# Altium schematic sheet BTT_M157_Interface1_V0.3.SchDoc(BTT_M157_Interface1_V0.3)
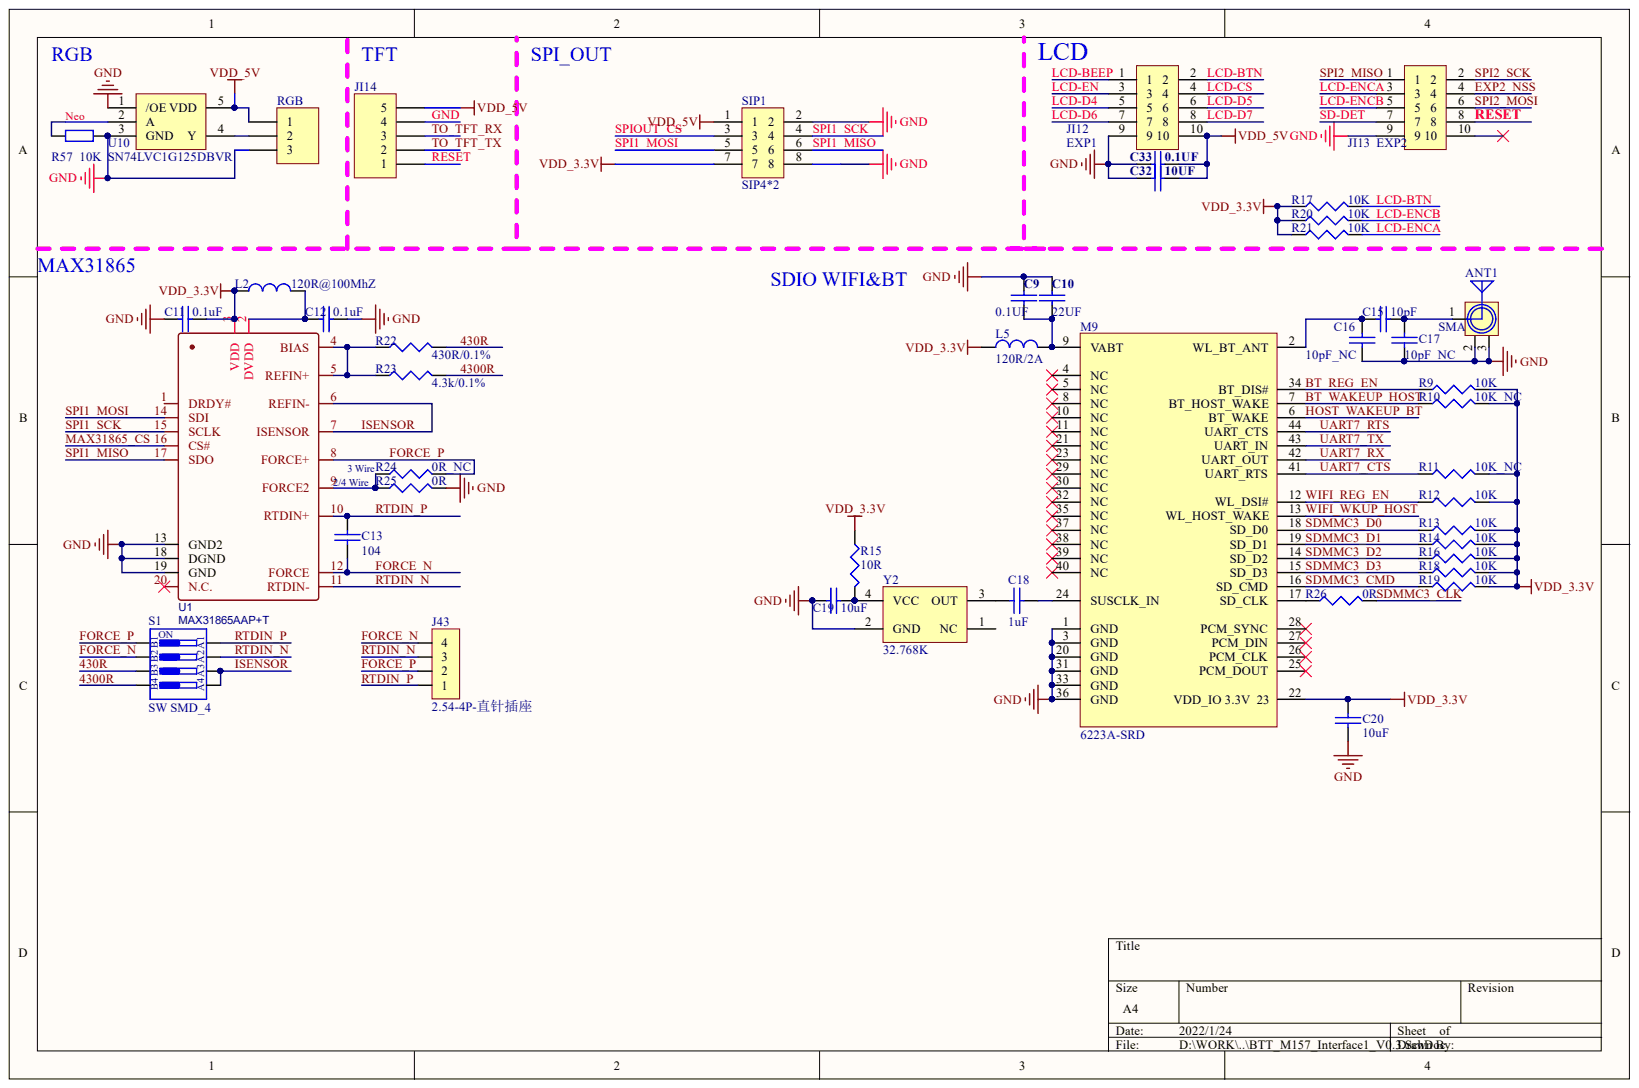
[diagram p0: BTT_M157_Interface1_V0.3.SchDoc]

## Parts
ANT1  SMA  [SMA-TH]
C9  0.1UF  [0603C]
C10  22UF  [0805C]
C11  0.1uF  [0603C]
C12  0.1uF  [0603C]
C13  104  [0603c]
C15  10pF  [0603C]
C16  10pF_NC  [0603C]
C17  10pF_NC  [0603C]
C18  1uF  [0603C]
C19  10uF  [0805C]
C20  10uF  [0805C]
C32  10UF  [0805C]
C33  0.1UF  [0603c]
J43  2.54-4P-直针插座  [2.54-4P-直针插座]
JI12  EXP1  [2*5_2.54-简牛插座]
JI13  EXP2  [2*5_2.54-简牛插座]
JI14  TFT  [SIP5-2.54-BLACK]
L2  120R@100MhZ  [0805L]
L5  120R/2A  [0603L]
M9  6223A-SRD  [Module_RTL8723DS]
R9  10K  [0603R]
R10  10K_NC  [0603R]
R11  10K_NC  [0603R]
R12  10K  [0603R]
R13  10K  [0603R]
R14  10K  [0603R]
R15  10R  [0603R]
R16  10K  [0603R]
R17  10K  [0603R]
R18  10K  [0603R]
R19  10K  [0603R]
R20  10K  [0603R]
R21  10K  [0603R]
R22  430R/0.1%  [0603R]
R23  4.3k/0.1%  [0603R]
R24  0R_NC  [0603R]
R25  0R  [0603R]
R26  0R  [0603R]
R57  value 10K  [0603-R]  (hidden comment: 4.7K)
RGB  2.54-3P-直针插座  [XH2.54-3P-直针插座]  (value not drawn)
S1  SW SMD_4  [SW_SMT_04_1.27]
SIP1  SIP4*2  [SIP4*2-2.54-BIACK]
U1  MAX31865AAP+T  [SSOP20]
U10  SN74LVC1G125DBVR  [sot23-5]
Y2  32.768K  [XTAL_3225]

## Nets
VDD_3.3V: C11.2 C20.1 L2.1 L5.1 M9.22 R9.2 R10.2 R11.2 R12.2 R13.2 R14.2 R15.1 R16.2 R17.1 R18.2 R19.2 R20.1 R21.1 SIP1.7 U1.3(VDD)
VDD_5V: C32.2 C33.1 JI12.10 JI14.5 RGB.1 SIP1.1 U10.5(VDD)
430R: R22.2 S1.6(B3)
4300R: R23.2 S1.5(B4)
BT_REG_EN: M9.34(BT_DIS#) R9.1
BT_WAKEUP_HOST: M9.7(BT_HOST_WAKE) R10.1
EXP2_NSS: JI13.4
FORCE_N: C13.2 J43.4 S1.7(B2) U1.12(FORCE)
FORCE_P: J43.2 R24.2 S1.8(B1) U1.8(FORCE+)
GND: ANT1.2 ANT1.3 C9.1 C10.1 C11.1 C12.2 C16.1 C17.1 C19.2 C20.2 C32.1 C33.2 JI12.9 JI13.9 JI14.4 M9.1(GND) M9.3(GND) M9.20(GND) M9.31(GND) M9.33(GND) M9.36(GND) R25.2 R57.1 RGB.3 SIP1.2 SIP1.8 U1.13(GND2) U1.18(DGND) U1.19(GND) U10.1(/OE) U10.3(GND) Y2.2(GND)
HOST_WAKEUP_BT: M9.6(BT_WAKE)
ISENSOR: S1.3(A3) S1.4(A4) U1.6(REFIN-) U1.7(ISENSOR)
LCD-BEEP: JI12.1
LCD-BTN: JI12.2 R17.2
LCD-CS: JI12.4
LCD-D4: JI12.5
LCD-D5: JI12.6
LCD-D6: JI12.7
LCD-D7: JI12.8
LCD-EN: JI12.3
LCD-ENCA: JI13.3 R21.2
LCD-ENCB: JI13.5 R20.2
MAX31865_CS: U1.16(CS#)
NEO: R57.2 U10.2(A)
RESET: JI13.8 JI14.1
RTDIN_N: J43.3 S1.2(A2) U1.11(RTDIN-)
RTDIN_P: C13.1 J43.1 S1.1(A1) U1.10(RTDIN+)
SD-DET: JI13.7
SDMMC3_CLK: R26.2
SDMMC3_CMD: M9.16(SD_CMD) R19.1
SDMMC3_D0: M9.18(SD_D0) R13.1
SDMMC3_D1: M9.19(SD_D1) R14.1
SDMMC3_D2: M9.14(SD_D2) R16.1
SDMMC3_D3: M9.15(SD_D3) R18.1
SPI1_MISO: SIP1.6 U1.17(SDO)
SPI1_MOSI: SIP1.5 U1.14(SDI)
SPI1_SCK: SIP1.4 U1.15(SCLK)
SPI2_MISO: JI13.1
SPI2_MOSI: JI13.6
SPI2_SCK: JI13.2
SPIOUT_CS: SIP1.3
TO_TFT_RX: JI14.3
TO_TFT_TX: JI14.2
UART7_CTS: M9.41(UART_RTS) R11.1
UART7_RTS: M9.44(UART_CTS)
UART7_RX: M9.42(UART_OUT)
UART7_TX: M9.43(UART_IN)
WIFI_REG_EN: M9.12(WL_DSI#) R12.1
WIFI_WKUP_HOST: M9.13(WL_HOST_WAKE)
NetANT1_1: ANT1.1 C15.2 C17.2
NetC9_2: C9.2 C10.2 L5.2 M9.9(VABT)
NetC12_1: C12.1 L2.2 U1.2(DVDD)
NetC15_1: C15.1 C16.2 M9.2(WL_BT_ANT)
NetC18_1: C18.1 Y2.3(OUT)
NetC18_2: C18.2 M9.24(SUSCLK_IN)
NetC19_1: C19.1 R15.2 Y2.4(VCC)
NetM9_17: M9.17(SD_CLK) R26.1
NetR22_1: R22.1 R23.1 U1.4(BIAS) U1.5(REFIN+)
NetR24_1: R24.1 R25.1 U1.9(FORCE2)
NetRGB_2: RGB.2 U10.4(Y)

Not connected: JI13 10; M9 4(NC) 5(NC) 8(NC) 10(NC) 11(NC) 21(NC) 23(NC) 25(PCM_DOUT) 26(PCM_CLK) 27(PCM_DIN) 28(PCM_SYNC) 29(NC) 30(NC) 32(NC) 35(NC) 37(NC) 38(NC) 39(NC) 40(NC); U1 1(DRDY#) 20(N.C.); Y2 1(NC)

## Library data of the parts
ANT1 = SMA: SMA-2.4G射频天线接口; 版权归属: 源创客; BOM名称: SMA-2.4G射频天线接口; Component Kind: Standard; 学习资源: www.ooocke.com; Library Name: My Useful Discrete Devices.SchLib
C9 C10 C32 C33 = CAP: Component Kind: Standard; Library Name: liroqi.SchLib
C11-C13 C15-C20 = CAP: Capacitor; Component Kind: Standard; Library Name: My Useful Discrete Devices.SchLib
J43 = CON4: Connector; Component Kind: Standard; Library Name: My Useful Discrete Devices.SchLib
JI12 JI13 = con2X5: Component Kind: Standard; Library Name: My Useful Discrete Devices.SchLib
JI14 = Header 5: Header, 5-Pin; Component Kind: Standard; LatestRevisionDate: 17-Jul-2002; LatestRevisionNote: Re-released for DXP Platform.; Library Name: Miscellaneous Connectors.IntLib; Signal Integrity: Connector; Publisher: Altium Limited
L2 L5 = INDUCTOR: Component Kind: Standard; Library Name: My Useful Discrete Devices.SchLib
M9 = 6223A-SRD: 欧智通SDIO  WIFI/BLE模块; Component Kind: Standard; Library Name: My Useful IC.SchLib
R9-R26 = RES1: Component Kind: Standard
  R9-R16 R18 R19 R22-R26: Library Name: My Useful Discrete Devices.SchLib
  R17 R20 R21: Library Name: 常用库.SCHLIB
R57 = Res2: Resistor; Component Kind: Standard; LatestRevisionDate: 17-Jul-2002; LatestRevisionNote: Re-released for DXP Platform.; Library Name: Miscellaneous Devices.IntLib; PackageDescription: Axial Device, Thru-Hole; 2 Leads; 0.4 in Pin Spacing; PackageReference: AXIAL-0.4; Published: 8-Jun-2000; Signal Integrity: Res; Simulation: RESISTOR; Publisher: Altium Limited
RGB = Header 3: Header, 3-Pin; Component Kind: Standard; LatestRevisionDate: 17-Jul-2002; LatestRevisionNote: Re-released for DXP Platform.; Library Name: Miscellaneous Connectors.IntLib; Signal Integrity: Connector; Publisher: Altium Limited
S1 = SW SMD_4: DIP Switch; Component Kind: Standard; Library Name: My Useful Discrete Devices.SchLib
SIP1 = con2X4: Component Kind: Standard; Library Name: My Useful Discrete Devices.SchLib
U1 = MAX31865AAP+T: BOM_Manufacturer: MAXIM(美信); Contributor: LCSC; BOM_JLCPCB Part Class: Extended Part; BOM_Manufacturer Part: MAX31865AAP+T; BOM_Supplier: LCSC; BOM_Supplier Part: C779509; Component Kind: Standard; spicePre: U; spiceSymbolName: MAX31865AAP+T; Library Name: My Useful IC.SchLib
U10 = SN74LVC1G125DBVR: 单路缓冲器; Component Kind: Standard; Library Name: My Useful IC.SchLib
Y2 = CRYSTAL2: Component Kind: Standard; Library Name: My Useful Discrete Devices.SchLib
